# Altium schematic sheet tx_mini_uc_and_tx.SchDoc(tx_mini_uc_and_tx)
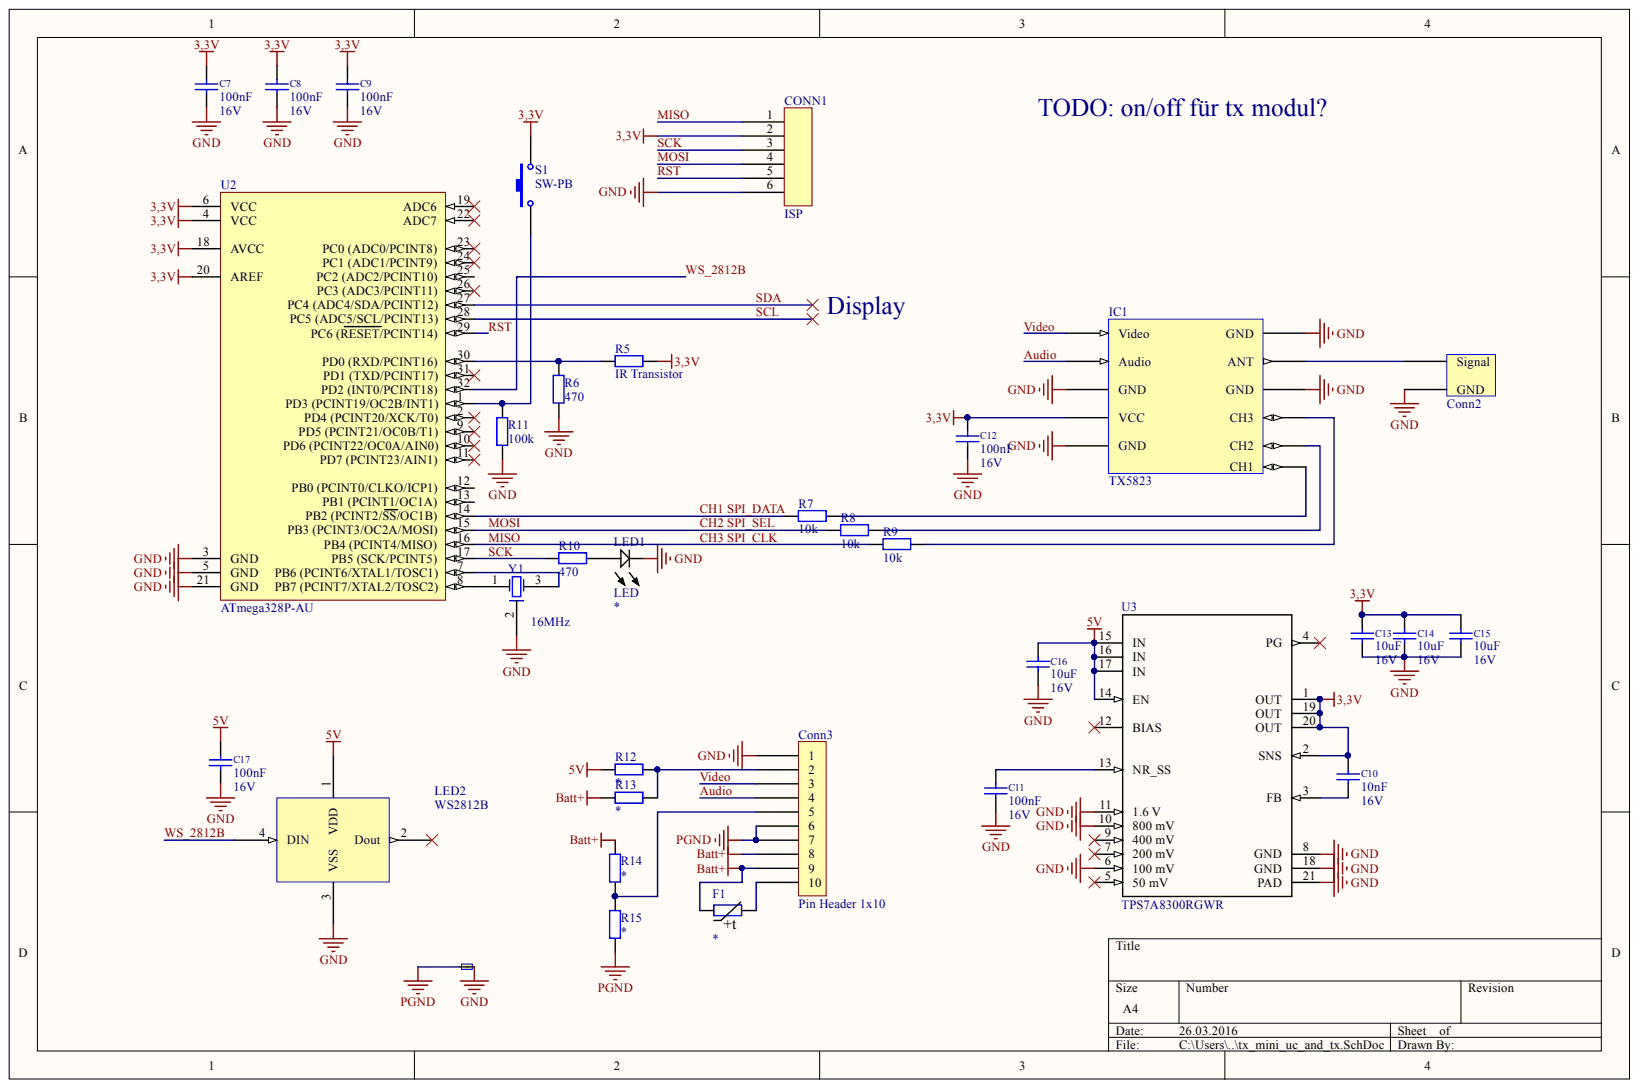
[diagram p0: tx_mini_uc_and_tx.SchDoc]

## Parts
1  Net Tie  [Net Tie 0201]  (value not drawn)
C7  100nF  Voltage: 16V  [C0402]
C8  100nF  Voltage: 16V  [C0402]
C9  100nF  Voltage: 16V  [C0402]
C10  10nF  Voltage: 16V  [C0402]
C11  100nF  Voltage: 16V  [C0402]
C12  100nF  Voltage: 16V  [C0402]
C13  10uF  Voltage: 16V  [C0805]
C14  10uF  Voltage: 16V  [C0805]
C15  10uF  Voltage: 16V  [C0805]
C16  10uF  Voltage: 16V  [C0805]
C17  100nF  Voltage: 16V  [C0402]
CONN1  ISP  [MICRO-MATCH SMD FTE 6]
Conn2  SMA  [SMA Straight]  (value not drawn)
Conn3  Pin Header 1x10  [Pin Header 1x10]
F1  PTC  [PTC 1812 (4532 metric)]  (value not drawn)
IC1  TX5823  [Tx5823]
LED1  LED  [LED-0603-GREEN]
LED2  WS2812B  [WS2812B]
R5  R  value IR Transistor  [RES1206]
R6  R  value 470  [RES0603]
R7  R  value 10k  [RES0603]
R8  R  value 10k  [RES0603]
R9  R  value 10k  [RES0603]
R10  R  value 470  [RES0603]
R11  R  value 100k  [RES0603]
R12  R  [RES0603]
R13  R  [RES0603]
R14  R  [RES0603]
R15  R  [RES0603]
S1  SW-PB  [pushbutton micro switch]
U2  ATmega328P-AU  [32A_N]
U3  TPS7A8300RGWR  [RGW20_N]
Y1  frequency: 16MHz  [CSTCE]  (hidden comment: Ceramic Resonators CSTCE)

## Nets
3,3V: C7.1 C8.1 C9.1 C10.1 C12.1 C13.1 C14.1 C15.1 CONN1.2 IC1.4(VCC) R5.2 S1.2 U2.4(VCC) U2.6(VCC) U2.18(AVCC) U2.20(AREF) U3.1(OUT) U3.2(SNS) U3.19(OUT) U3.20(OUT)
5V: C16.1 C17.1 LED2.1(VDD) R12.1 U3.14(EN) U3.15(IN) U3.16(IN) U3.17(IN)
BATT+: Conn3.8 Conn3.9 F1.1 R13.1 R14.2
GND: 1.2 C7.2 C8.2 C9.2 C11.2 C12.2 C13.2 C14.2 C15.2 C16.2 C17.2 CONN1.6 Conn2.1 Conn3.1 IC1.3(GND) IC1.5(GND) IC1.9(GND) IC1.11(GND) LED1.K LED2.3(VSS) R6.1 R11.1 U2.3(GND) U2.5(GND) U2.21(GND) U3.6(100_mV) U3.8(GND) U3.10(800_mV) U3.11(1.6_V) U3.18(GND) U3.21(PAD) Y1.2
PGND: 1.1 Conn3.6 Conn3.7 R15.1
AUDIO: Conn3.4 IC1.2(Audio)
CH1 SPI_DATA: R7.1 U2.14(PB2_(PCINT2/SS/OC1B))
CH2 SPI_SEL: CONN1.4 R8.1 U2.15(PB3_(PCINT3/OC2A/MOSI))
CH3 SPI_CLK: CONN1.1 R9.1 U2.16(PB4_(PCINT4/MISO))
RST: CONN1.5 U2.29(PC6_(RESET/PCINT14))
SCK: CONN1.3 R10.1 U2.17(PB5_(SCK/PCINT5))
SCL: U2.28(PC5_(ADC5/SCL/PCINT13))
SDA: U2.27(PC4_(ADC4/SDA/PCINT12))
VIDEO: Conn3.3 IC1.1(Video)
WS_2812B: LED2.4(DIN) U2.32(PD2_(INT0/PCINT18))
NetC10_2: C10.2 U3.3(FB)
NetC11_1: C11.1 U3.13(NR_SS)
NetConn2_2: Conn2.2 IC1.10(ANT)
NetConn3_2: Conn3.2 R12.2 R13.2
NetConn3_5: Conn3.5 R14.1 R15.2
NetConn3_10: Conn3.10 F1.2
NetIC1_6: IC1.6(CH1) R7.2
NetIC1_7: IC1.7(CH2) R8.2
NetIC1_8: IC1.8(CH3) R9.2
NetLED1_A: LED1.A R10.2
NetR5_1: R5.1 R6.2 U2.30(PD0_(RXD/PCINT16))
NetR11_2: R11.2 S1.1 U2.1(PD3_(PCINT19/OC2B/INT1))
NetU2_7: U2.7 Y1.3
NetU2_8: U2.8 Y1.1

Not connected: LED2 2(Dout); U2 2(PD4_(PCINT20/XCK/T0)) 9(PD5_(PCINT21/OC0B/T1)) 10(PD6_(PCINT22/OC0A/AIN0)) 11(PD7_(PCINT23/AIN1)) 12(PB0_(PCINT0/CLKO/ICP1)) 13(PB1_(PCINT1/OC1A)) 19(ADC6) 22(ADC7) 23(PC0_(ADC0/PCINT8)) 24(PC1_(ADC1/PCINT9)) 25 26(PC3_(ADC3/PCINT11)) 31(PD1_(TXD/PCINT17)); U3 4(PG) 5(50_mV) 7(200_mV) 9(400_mV) 12(BIAS)

## Library data of the parts
C7-C9 C11 C12 C17 = C 100nF 0402: Capacitor; Component Kind: Standard; Value: 100nF; Library Name: Toni.IntLib
C10 C13-C16 = C: Capacitor; Component Kind: Standard; Library Name: Toni.IntLib
  C10: Value: 10nF
  C13-C16: Value: 10uF
CONN1 = Micromatch 02x03 SMD: Micromatch; Herstellernummer: 188275-6; Component Kind: Standard; Library Name: Toni.IntLib
LED2 = WS2812B: RGB LED with serial in and out; Component Kind: Standard; Library Name: Toni.IntLib
R5 = R: Resistor; Herst.Bez.: PT15-21B/TR8; Component Kind: Standard; Library Name: Toni.IntLib
R6-R15 = R: Resistor; Component Kind: Standard; Library Name: Toni.IntLib
S1 = SW-PB: Switch; Component Kind: Standard; LatestRevisionDate: 17-Jul-2002; LatestRevisionNote: Re-released for DXP Platform.; PackageDescription: Switch; 2 Leads; PackageReference: SPST-2; Published: 24-Mar-1999; Library Name: Toni.IntLib; Publisher: Altium Limited
U2 = ATmega328P-AU: 8-bit AVR Microcontroller, 32KB Flash, 1KB EEPROM, 2KB SRAM, 32-pin TQFP, Industrial Grade (-40°C to 85°C); Code_JEDEC: MS-026-ABA; Component Kind: Standard; ComponentLink1Description: Manufacturer Link; ComponentLink1URL: http://www.atmel.com/products/avr/default.asp?; ComponentLink2Description: ATmega48A/48PA/88A/88PA/168A/168PA/328/328P Datasheet; ComponentLink2URL: http://www.atmel.com/dyn/resources/prod_documents/8271.pdf; ComponentLink3Description: ATmega48A/48PA/88A/88PA/168A/168PA/328/328P Summary; ComponentLink3URL: http://www.atmel.com/dyn/resources/prod_documents/8271S.pdf; ComponentLink4Description: Atmel.com Home; ComponentLink4URL: http://www.atmel.com; DatasheetVersion: revA, Jan-2010; PackageDescription: 32-Lead, 7x7x1.0 mm Body, 0.8mm Lead Pitch, Thin Profile Plastic Quad Flat Package (TQFP); PackageReference: 32A; PackageVersion: revB, Oct-2001; Published: 1-Apr-2010; Supplier 1: Digi-Key; Supplier 2: Farnell; Supplier 3: Mouser; Supplier Part Number 1: ATMEGA328P-AU-ND; Supplier Part Number 2: 1715486; Supplier Part Number 3: 556-ATMEGA328P-AU; Library Name: Toni.IntLib; Publisher: Altium Limited
U3 = TPS7A8300RGWR: Imported; Manufacturer: Texas Instruments; CompType: IC; PIN_COUNT: 21; Component Kind: Standard; MountType: SMT; PackageReference: RGW0020A; PartNumber: TPS7A8300RGW; RefDes: RefDes; RoHS: Y; Type: DEV; Value: Value; Library Name: Toni.IntLib
1 = Net Tie: Component Kind: Net Tie; Library Name: Toni.IntLib
Conn2 = SMA: Component Kind: Standard; Library Name: Toni.IntLib
Conn3 = Pin Header 1x10: Component Kind: Standard; Library Name: Toni.IntLib
F1 = PTC: Component Kind: Standard; Description: PTC; Library Name: Toni.IntLib
IC1 = TX5823: Component Kind: Standard; Library Name: Toni.IntLib
LED1 = LED: Component Kind: Standard; Description: LED; Library Name: Toni.IntLib
Y1 = Ceramic Resonators CSTCE: Component Kind: Standard; Description: Ceramic Resonators CSTCE; Library Name: Toni.IntLib
